# Altium schematic sheet 102-002-00136-00,K12火焰传感器V1.0原理图.SchDoc
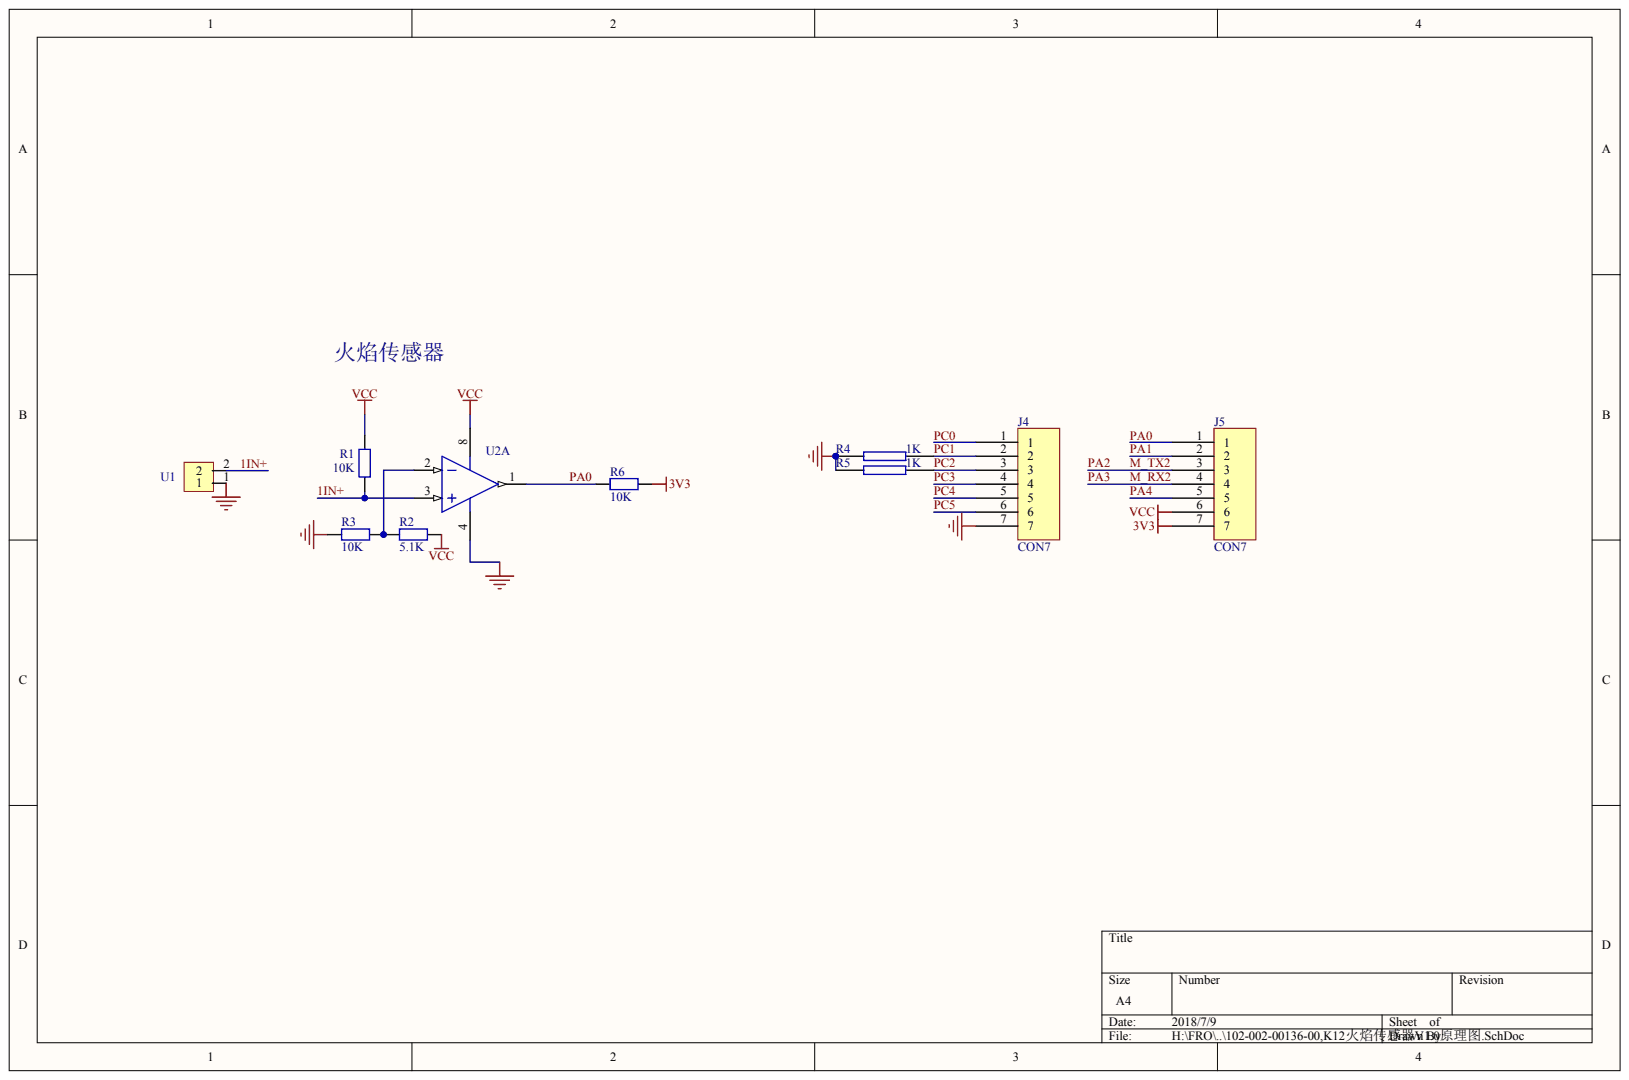
[diagram p0: 102-002-00136-00,K12火焰传感器V1.0原理图.SchDoc]

## Parts
J4  CON7
J5  CON7
R1  10K
R2  5.1K
R3  10K
R4  1K
R5  1K
R6  10K
U1  SW-18010P  (value not drawn)
U2  LM393  (value not drawn)  (part A)

## Nets
3V3: J5.7 R6.2
GND: J4.7 R3.2 R4.1 R5.1 U1.1 U2.4
VCC: J5.6 R1.1 R2.1 U2.8
1IN+: R1.2 U1.2 U2.3
M_RX2: J5.4
M_TX2: J5.3
PA0: J5.1 R6.1 U2.1
PA1: J5.2
PA4: J5.5
PC0: J4.1
PC1: J4.2 R4.2
PC2: J4.3 R5.2
PC3: J4.4
PC4: J4.5
PC5: J4.6
NetR2_2: R2.2 R3.1 U2.2

## Library data of the parts
R1 R3 R6 = (no symbol name): wuliaoguige: 0805/10KΩ/F; wuliaobianma: 103-001-00103-01; wuliaomingchen: 贴片电阻
R2 = (no symbol name): wuliaoguige: 0805/5.1kΩ/F; wuliaobianma: 103-001-00512-01; wuliaomingchen: 贴片电阻
R4 R5 = (no symbol name): wuliaobianma: 103-001-00102-01; wuliaoguige: 0805/1KΩ/F; wuliaomingchen: 贴片电阻
U1 = (no symbol name): wuliaoguige: PD333-3B/5mm/波长 850-940nm; wuliaomingchen: 红外接收管; wuliaobianma: 199-008-00008-00
U2 = (no symbol name): wuliaobianma: 101-001-00032-00; wuliaoguige: LM393/SOP8; wuliaomingchen: 贴片芯片
